# Altium schematic sheet Power Monitor.SchDoc
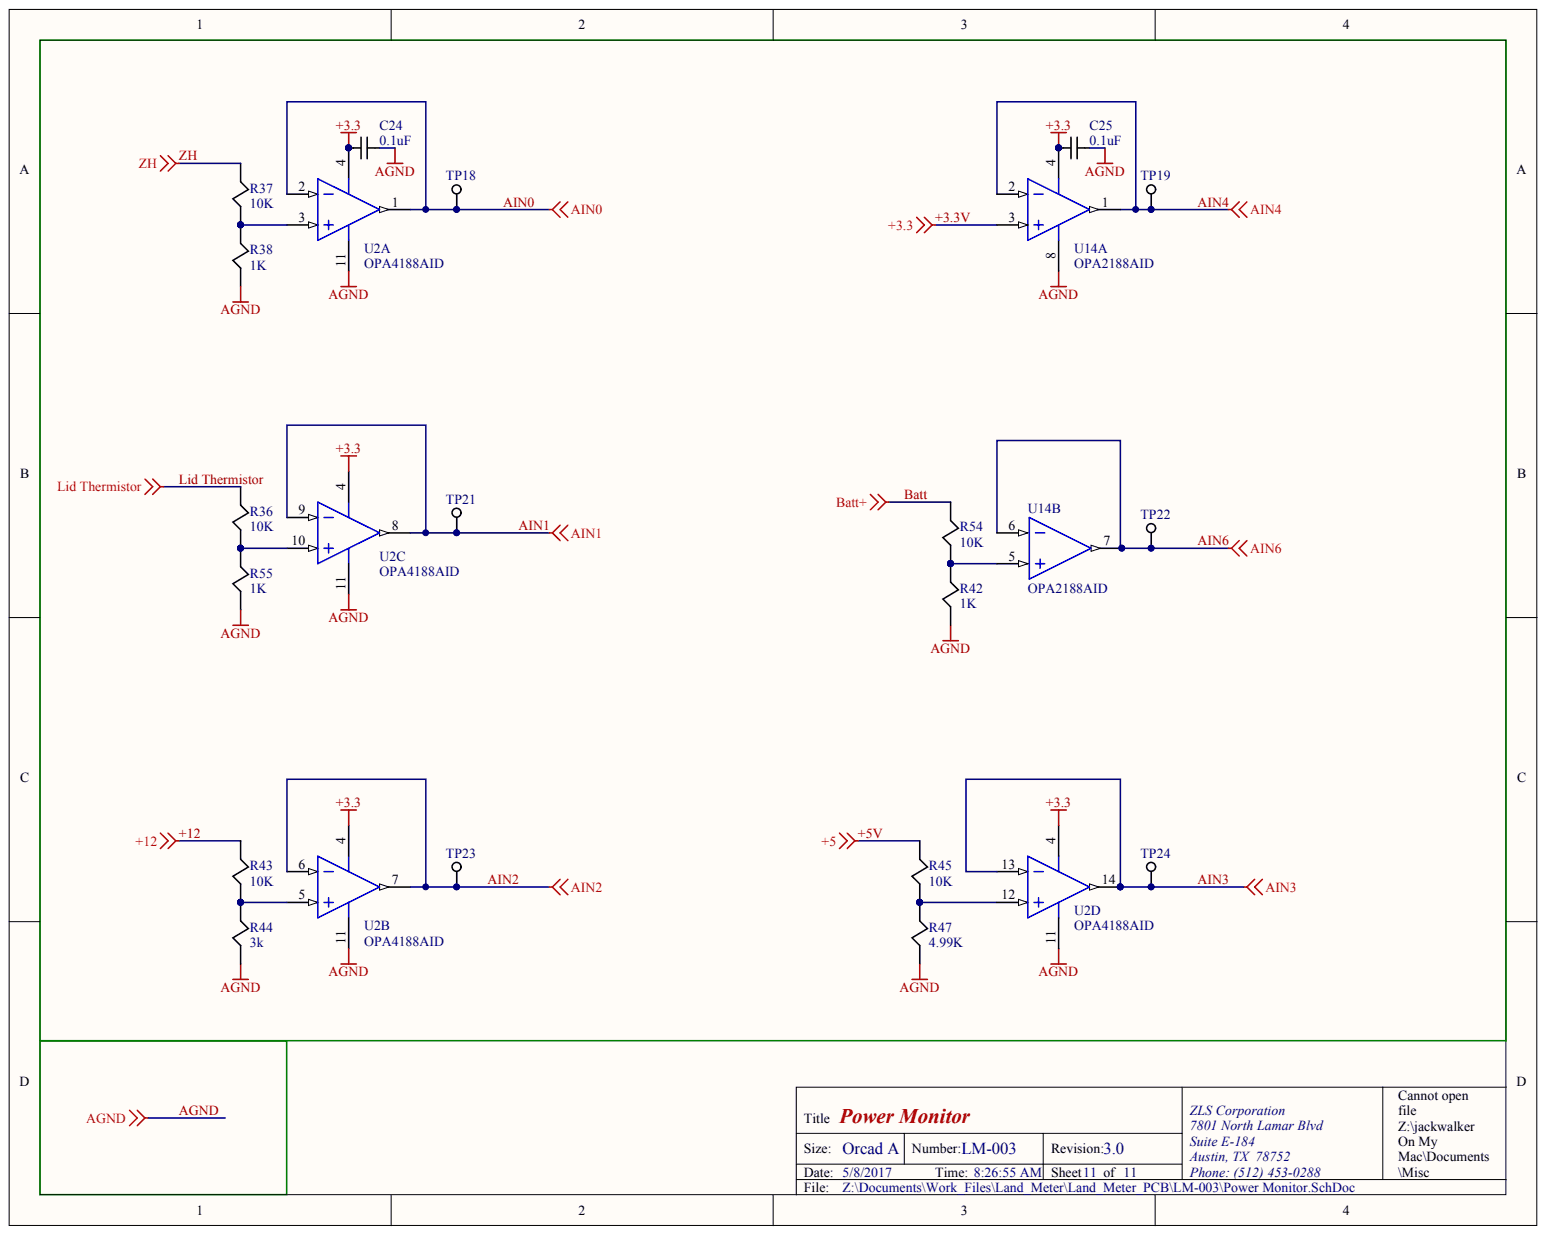
[diagram p0: Power Monitor.SchDoc]

## Parts
C24  0.1uF
C25  0.1uF
R36  10K
R37  10K
R38  1K
R42  1K
R43  10K
R44  3k
R45  10K
R47  4.99K
R54  10K
R55  1K
TP18  (no value)
TP19  (no value)
TP21  (no value)
TP22  (no value)
TP23  (no value)
TP24  (no value)
U2  OPA4188AID  (parts A,B,C,D)
U14  OPA2188AID  (parts A,B)

## Nets
+3.3: C24.1 C25.1 U2.4 U14.4
+3.3V: U14.3
+5V: R45.2
+12: R43.2
AGND: C24.2 C25.2 R38.1 R42.1 R44.1 R47.1 R55.1 U2.11
AIN0: TP18.1 U2.1 U2.2
AIN1: TP21.1 U2.8 U2.9
AIN2: TP23.1 U2.6 U2.7
AIN3: TP24.1 U2.13 U2.14
AIN4: TP19.1 U14.1 U14.2
AIN6: TP22.1 U14.6 U14.7
BATT: R54.2
LID THERMISTOR: R36.2
ZH: R37.2
NetR36_1: R36.1 R55.2 U2.10
NetR37_1: R37.1 R38.2 U2.3
NetR42_2: R42.2 R54.1 U14.5
NetR43_1: R43.1 R44.2 U2.5
NetR45_1: R45.1 R47.2 U2.12

Not connected: U14 8

## Library data of the parts
C24 C25 = (no symbol name): Manufacturer 1: Murata Electronics North America; Manufacturer Part Number 1: GRM21BR71E104KA01L; Description 1: CAP CER 0.1UF 25V X7R 0805; Category 1: Capacitors; Capacitance: 0.1µF; Tolerance: ±10%; Voltage - Rated: 25V; Temperature Coefficient: X7R; Supplier 1: Digi-Key; Supplier Part Number 1: 490-1673-1-ND; RoHS 1: RoHS Compliant; Stock 1: 897437; Pricing 1: 1=0.2, 10=0.145, 25=0.106, 50=0.0824, 100=0.0683, 250=0.05652, 500=0.04788, 1000=0.03964 (USD); Packaging: Cut Tape (CT); Part Status: Active; Operating Temperature: -55°C ~ 125°C; Features: -; Ratings: -; Applications: General Purpose; Mounting Type: Surface Mount, MLCC; Package / Case: 0805 (2012 Metric); Size / Dimension: 0.079" L x 0.049" W (2.00mm x 1.25mm); Height - Seated (Max): -; Thickness (Max): 0.053" (1.35mm); Lead Spacing: -; Lead Style: -; ComponentLink1URL: http://www.murata.com/~/media/webrenewal/support/library/catalog/products/capacitor/mlcc/c02e.ashx; ComponentLink1Description: http://www.murata.com/~/media/webrenewal/support/library/catalog/products/capacitor/mlcc/c02e.ashx
R36 R37 R43 R45 R54 = (no symbol name): Manufacturer 1: Vishay Dale; Manufacturer Part Number 1: CRCW080510K0FKTA; Description 1: RES SMD 10K OHM 1% 1/8W 0805; Category 1: Resistors; Tolerance: ±1%; Power (Watts): 0.125W, 1/8W; Temperature Coefficient: ±100ppm/°C; Supplier 1: Digi-Key; Supplier Part Number 1: 541-3016-1-ND; RoHS 1: RoHS non-compliant; Stock 1: 11388; Pricing 1: 1=0.14, 10=0.123, 25=0.0852, 50=0.0662, 100=0.0474, 250=0.03692, 500=0.02936, 1000=0.02084 (USD); Packaging: Cut Tape (CT); Part Status: Active; Resistance (Ohms): 10k; Composition: Thick Film; Features: Automotive AEC-Q200; Operating Temperature: -55°C ~ 155°C; Package / Case: 0805 (2012 Metric); Supplier Device Package: 0805; Size / Dimension: 0.079" L x 0.049" W (2.00mm x 1.25mm); Height - Seated (Max): 0.020" (0.50mm); Failure Rate: -; ComponentLink1URL: http://www.vishay.com/docs/20008/dcrcw.pdf; ComponentLink1Description: http://www.vishay.com/docs/20008/dcrcw.pdf
R38 R42 R55 = (no symbol name): Manufacturer 1: Vishay Dale; Manufacturer Part Number 1: CRCW080510K0FKEC; Description 1: RES SMD 10K OHM 1% 1/8W 0805; Category 1: Resistors; Resistance (Ohms): 10k; Tolerance: ±1%; Power (Watts): 0.125W, 1/8W; Temperature Coefficient: ±100ppm/°C; Supplier 1: Digi-Key; Supplier Part Number 1: 541-3015-1-ND; RoHS 1: RoHS Compliant; Stock 1: 13410; Pricing 1: 1=0.1, 10=0.042, 25=0.0304, 50=0.0232, 100=0.017, 250=0.013, 500=0.0104, 1000=0.00765, 5000=0.00549 (USD); Packaging: Cut Tape (CT); Part Status: Active; Composition: Thick Film; Features: Automotive AEC-Q200; Operating Temperature: -55°C ~ 155°C; Package / Case: 0805 (2012 Metric); Supplier Device Package: 0805; Size / Dimension: 0.079" L x 0.049" W (2.00mm x 1.25mm); Height - Seated (Max): 0.020" (0.50mm); Failure Rate: -; ComponentLink1URL: http://www.vishay.com/docs/20035/dcrcwe3.pdf; ComponentLink1Description: http://www.vishay.com/docs/20035/dcrcwe3.pdf
R44 = (no symbol name): Manufacturer 1: Vishay Dale; Manufacturer Part Number 1: CRCW08053K00FKEA; Description 1: RES SMD 3K OHM 1% 1/8W 0805; Category 1: Resistors; Tolerance: ±1%; Power (Watts): 0.125W, 1/8W; Temperature Coefficient: ±100ppm/°C; Supplier 1: Digi-Key; Supplier Part Number 1: 541-3.00KCCT-ND; RoHS 1: RoHS Compliant; Stock 1: 1820; Pricing 1: 1=0.1, 10=0.042, 25=0.0304, 50=0.0232, 100=0.017, 250=0.013, 500=0.0104, 1000=0.00765 (USD); Packaging: Cut Tape (CT); Part Status: Active; Resistance (Ohms): 3k; Composition: Thick Film; Features: Automotive AEC-Q200; Operating Temperature: -55°C ~ 155°C; Package / Case: 0805 (2012 Metric); Supplier Device Package: 0805; Size / Dimension: 0.079" L x 0.049" W (2.00mm x 1.25mm); Height - Seated (Max): 0.020" (0.50mm); Failure Rate: -; ComponentLink1URL: http://www.vishay.com/docs/20035/dcrcwe3.pdf; ComponentLink1Description: http://www.vishay.com/docs/20035/dcrcwe3.pdf
R47 = (no symbol name): Manufacturer 1: Vishay Dale; Manufacturer Part Number 1: CRCW08054K99FKTA; Description 1: RES SMD 4.99K OHM 1% 1/8W 0805; Category 1: Resistors; Tolerance: ±1%; Power (Watts): 0.125W, 1/8W; Temperature Coefficient: ±100ppm/°C; Supplier 1: Digi-Key; Supplier Part Number 1: 541-3030-1-ND; RoHS 1: RoHS non-compliant; Stock 1: 20806; Pricing 1: 1=0.14, 10=0.123, 25=0.0852, 50=0.0662, 100=0.0474, 250=0.03692, 500=0.02936, 1000=0.02084 (USD); Packaging: Cut Tape (CT); Part Status: Active; Resistance (Ohms): 4.99k; Composition: Thick Film; Features: Automotive AEC-Q200; Operating Temperature: -55°C ~ 155°C; Package / Case: 0805 (2012 Metric); Supplier Device Package: 0805; Size / Dimension: 0.079" L x 0.049" W (2.00mm x 1.25mm); Height - Seated (Max): 0.020" (0.50mm); Failure Rate: -; ComponentLink1URL: http://www.vishay.com/docs/20008/dcrcw.pdf; ComponentLink1Description: http://www.vishay.com/docs/20008/dcrcw.pdf
TP18 TP19 TP21-TP24 = (no symbol name): Manufacturer 1: Keystone Electronics; Manufacturer Part Number 1: 5001; Description 1: TEST POINT PC MINI .040"D BLACK; Category 1: Test and Measurement; Plating: Silver; Material - Insulation: Nylon 46, UL 94V-0; Material - Body: Phosphor Bronze; Supplier 1: Digi-Key; Supplier Part Number 1: 36-5001-ND; RoHS 1: RoHS Compliant; Stock 1: 883882; Pricing 1: 1=0.37, 10=0.316, 50=0.2298, 100=0.2209, 250=0.19832, 500=0.18932, 1000=0.1616, 2500=0.14424, 5000=0.13523 (USD); Packaging: Bulk; Part Status: Active; Type: PC Test Point, Miniature; Mounting Type: 0.040" (1.02mm) Hole Diameter; Color: Black; Size / Dimension: 0.100" Dia x 0.180" L (2.54mm x 4.57mm); Height: -; ComponentLink1URL: http://www.keyelco.com/product-pdf.cfm?p=1310; ComponentLink1Description: http://www.keyelco.com/product-pdf.cfm?p=1310
U2 = (no symbol name): Manufacturer: Texas Instruments; CMRR(Min)(dB): 120; Eco-Plan: Green (RoHS & no Sb/Br); GBW(Typ)(MHz): 2; Iq per channel(Max)(mA): 0.475; Number of Channels: 4; Offset Drift(Typ)(uV/C): 0.03; Packing: TUBE; Rating: Catalog; Slew Rate(Typ)(V/us): 0.8; SubFamily: High Supply Voltage Amplifier (>=30V), Low Noise Amp (<=10nV/rtHz), Low Offset Voltage Amplifier (<=500uV), Low Power Amp (<=500uA), Zero Drift Precision Amplifier; Total Supply Voltage(Max)(+5V is 5, +/-5V is 10): 36; DatasheetVersion: SBOS641B; PackageVersion: 4040047-5/M, 06/2011; PackageReference: D14; PackageDescription: 14-Pin Plastic Small Outline, Body 8.65 x 3.9 mm, Pitch 1.27 mm; Supplier 1: Digi-Key; Supplier Part Number 1: 296-34857-5-ND; Supplier 2: Farnell; Supplier Part Number 2: 2211327; Supplier 3: Newark; Supplier Part Number 3: 44W4374; Supplier 4: Newark; Supplier Part Number 4: 27W9605; Supplier 5: Mouser; Supplier Part Number 5: 595-OPA4188AID; Total Supply Voltage(Min)(+5V is 5, +/-5V is 10): 4; Mounting Technology: Surface Mount; Code_JEDEC: MS-012; PartNumber: OPA4188AID; ComponentLink1Description: Manufacturer URL; ComponentLink1URL: http://www.ti.com/; ComponentLink2Description: Datasheet; ComponentLink2URL: http://www.ti.com/lit/ds/symlink/opa4188.pdf; ComponentLink3Description: Package Specification; ComponentLink3URL: http://www.ti.com/lit/ml/mpds177g/mpds177g.pdf
U14 = (no symbol name): Manufacturer 1: Texas Instruments; Description 1: IC OPAMP ZERO-DRIFT 2MHZ 8SOIC; Category 1: Integrated Circuits (ICs); Amplifier Type: Zero-Drift; Number of Circuits: 2; Output Type: Rail-to-Rail; Slew Rate: 0.8 V/µs; Current - Supply: 415µA; Current - Output / Channel: 18mA; Voltage - Supply, Single/Dual (±): 4 V ~ 36 V, ±2 V ~ 18 V; Supplier 1: Digi-Key; Supplier Part Number 1: 296-36123-5-ND; Manufacturer Part Number 1: OPA2188AID; RoHS 1: RoHS Compliant; Stock 1: 618; Pricing 1: 1=4.05, 10=3.641, 100=2.9835, 500=2.5398, 1000=2.142 (USD); Packaging: Tube; Part Status: Active; -3db Bandwidth: -; Operating Temperature: -40°C ~ 105°C; Mounting Type: Surface Mount; Package / Case: 8-SOIC (0.154", 3.90mm Width); Supplier Device Package: 8-SOIC; ComponentLink1URL: http://www.ti.com/lit/ds/symlink/opa2188.pdf; ComponentLink1Description: http://www.ti.com/lit/ds/symlink/opa2188.pdf
